# Altium schematic sheet 01_INTERFACE_CAN_0820.SchDoc
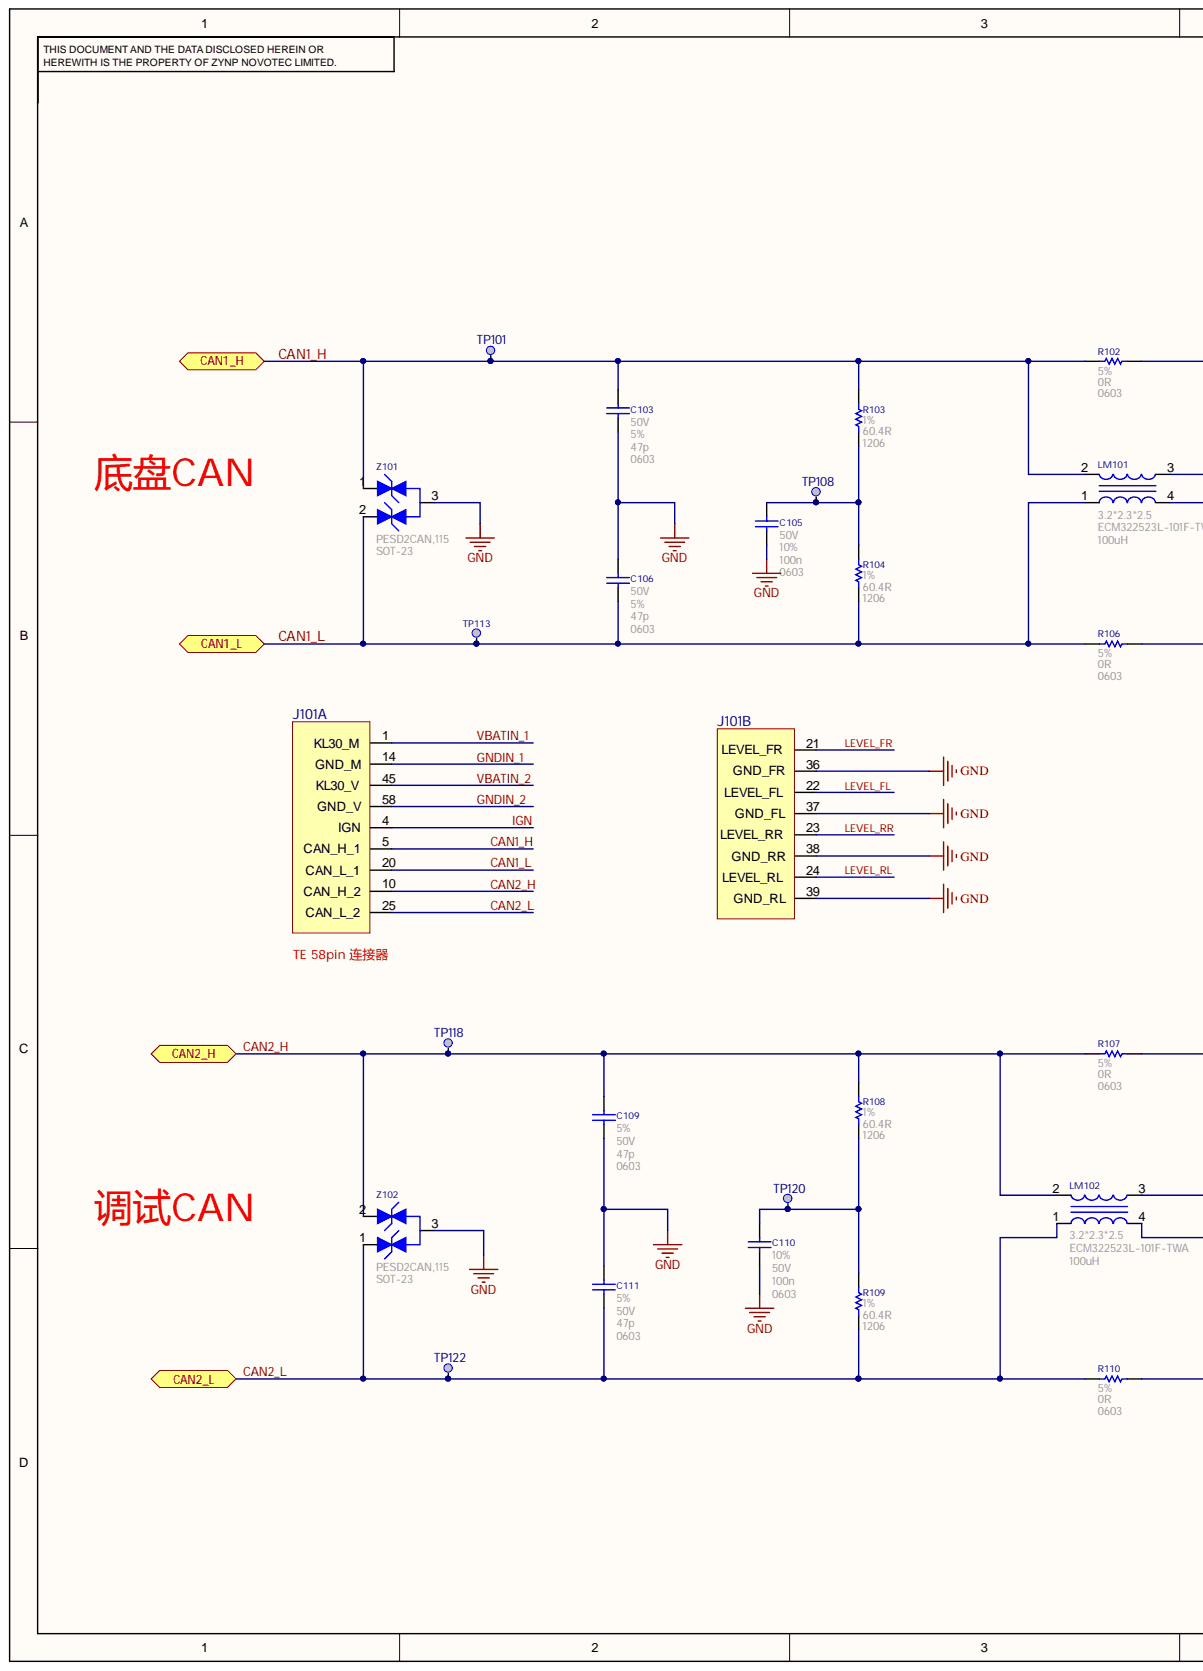
[diagram p0: 01_INTERFACE_CAN_0820.SchDoc, left]
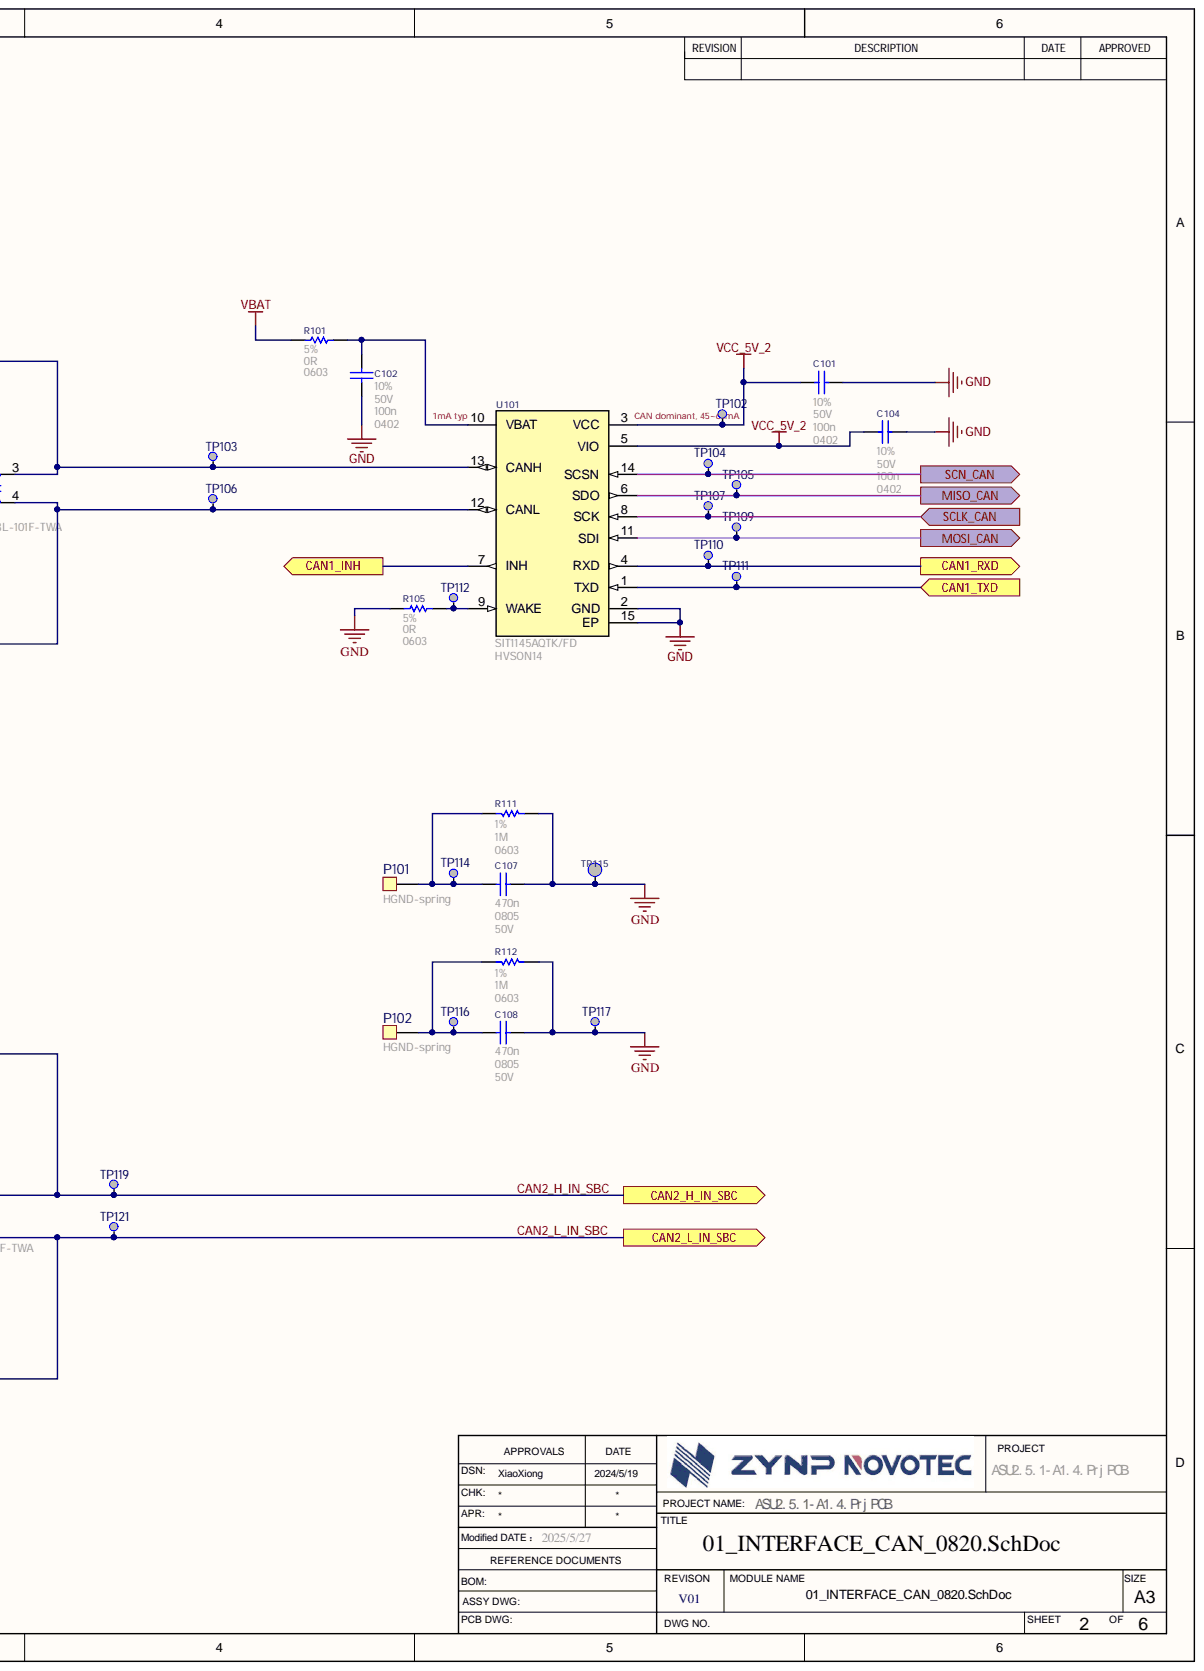
[diagram p1: 01_INTERFACE_CAN_0820.SchDoc, right]

## Parts
C101  value 100n  Tolerance: 10%  Voltage: 50V  [C0402]  (hidden comment: CAP)
C102  value 100n  Package: 0402  Tolerance: 10%  Voltage: 50V  [C0402]  (hidden comment: CAP)
C103  value 47p  Package: 0603  Tolerance: 5%  Voltage: 50V  [C0603]  (hidden comment: CAP)
C104  value 100n  Package: 0402  Tolerance: 10%  Voltage: 50V  [C0402]  (hidden comment: CAP)
C105  value 100n  Package: 0603  Tolerance: 10%  Voltage: 50V  [C0603]  (hidden comment: CAP)
C106  value 47p  Package: 0603  Tolerance: 5%  Voltage: 50V  [C0603]  (hidden comment: CAP)
C107  value 470n  Package: 0805  [C0805]  (hidden comment: CAP)
C108  value 470n  Package: 0805  Voltage: 50V  [C0805]  (hidden comment: CAP)
C109  value 47p  Package: 0603  Tolerance: 5%  Voltage: 50V  [C0603]  (hidden comment: CAP)
C110  value 100n  Package: 0603  Tolerance: 10%  Voltage: 50V  [C0603]  (hidden comment: CAP)
C111  value 47p  Package: 0603  Tolerance: 5%  Voltage: 50V  [C0603]  (hidden comment: CAP)
J101  (no value)  [CON_46 - 17PIN]  (parts A,B)
LM101  value 100uH  Package: 3.2*2.3*2.5  Part_number: ECM322523L-101F-TWA  [L-3.2*2.5]  (hidden comment: CAN Choke)
LM102  value 100uH  Package: 3.2*2.3*2.5  Part_number: ECM322523L-101F-TWA  [L-3.2*2.5]  (hidden comment: CAN Choke)
P101  HGND-spring  [PAD-HGND-SPRING]
P102  HGND-spring  [PAD-HGND-SPRING]
R101  value 0R  Package: 0603  Tolerance: 5%  [R0603]  (hidden comment: Res)
R102  value 0R  Package: 0603  Tolerance: 5%  [R0603]  (hidden comment: Res)
R103  value 60.4R  Package: 1206  Tolerance: 1%  [R1206]  (hidden comment: Res)
R104  value 60.4R  Package: 1206  Tolerance: 1%  [R1206]  (hidden comment: Res)
R105  value 0R  Package: 0603  Tolerance: 5%  [R0603]  (hidden comment: Res)
R106  value 0R  Package: 0603  Tolerance: 5%  [R0603]  (hidden comment: Res)
R107  value 0R  Package: 0603  Tolerance: 5%  [R0603]  (hidden comment: Res)
R108  value 60.4R  Package: 1206  Tolerance: 1%  [R1206]  (hidden comment: Res)
R109  value 60.4R  Package: 1206  Tolerance: 1%  [R1206]  (hidden comment: Res)
R110  value 0R  Package: 0603  Tolerance: 5%  [R0603]  (hidden comment: Res)
R111  value 1M  Tolerance: 1%  [R0603]  (hidden comment: Res)
R112  value 1M  Tolerance: 1%  [R0603]  (hidden comment: Res)
TP101  TEST Point  [TP-0.9]  (value not drawn)
TP102  TEST Point  [TP-0.9]  (value not drawn)
TP103  TEST Point  [TP-0.9]  (value not drawn)
TP104  TEST Point  [TP-0.9]  (value not drawn)
TP105  TEST Point  [TP-0.9]  (value not drawn)
TP106  TEST Point  [TP-0.9]  (value not drawn)
TP107  TEST Point  [TP-0.9]  (value not drawn)
TP108  TEST Point  [TP-0.9]  (value not drawn)
TP109  TEST Point  [TP-0.9]  (value not drawn)
TP110  TEST Point  [TP-0.9]  (value not drawn)
TP111  TEST Point  [TP-0.9]  (value not drawn)
TP112  TEST Point  [TP-0.9]  (value not drawn)
TP113  TEST Point  [TP-0.9]  (value not drawn)
TP114  TEST Point  [TP-0.9]  (value not drawn)
TP115  TEST Point  [PAD_1.5]  (value not drawn)
TP116  TEST Point  [TP-0.9]  (value not drawn)
TP117  TEST Point  [TP-0.9]  (value not drawn)
TP118  TEST Point  [TP-0.9]  (value not drawn)
TP119  TEST Point  [TP-0.9]  (value not drawn)
TP120  TEST Point  [TP-0.9]  (value not drawn)
TP121  TEST Point  [TP-0.9]  (value not drawn)
TP122  TEST Point  [TP-0.9]  (value not drawn)
U101  value SIT1145AQTK/FD  Part_number: SIT1145AQTK/FD  [HVSON14]  (hidden comment: CAN Transceiver)
Z101  Part_number: PESD2CAN,115  [SOT-23]  (hidden comment: Diode)
Z102  Part_number: PESD2CAN,115  [SOT-23]  (hidden comment: Diode)

## Ports
CAN1_H, CAN1_INH, CAN1_L, CAN1_RXD, CAN1_TXD, CAN2_H, CAN2_H_IN_SBC, CAN2_L, CAN2_L_IN_SBC, MISO_CAN, MOSI_CAN, SCLK_CAN, SCN_CAN

## Nets
GND: C101.1 C102.1 C103.1 C104.1 C105.1 C106.2 C107.2 C108.2 C109.1 C110.1 C111.2 J101.36(GND_FR) J101.37(GND_FL) J101.38(GND_RR) J101.39(GND_RL) R105.1 R111.2 R112.2 TP115.1 TP117.1 U101.2(GND) U101.15(EP) Z101.3 Z102.3
VBAT: R101.1
VCC_5V_2: C101.2 C104.2 TP102.1 U101.3(VCC) U101.5(VIO)
CAN1_H: C103.2 J101.5(CAN_H_1) LM101.2 R102.1 R103.2 TP101.1 Z101.1 ; port CAN1_H
CAN1_INH: U101.7(INH) ; port CAN1_INH
CAN1_L: C106.1 J101.20(CAN_L_1) LM101.1 R104.1 R106.1 TP113.1 Z101.2 ; port CAN1_L
CAN1_RXD: TP110.1 U101.4(RXD) ; port CAN1_RXD
CAN1_TXD: TP111.1 U101.1(TXD) ; port CAN1_TXD
CAN2_H: C109.2 J101.10(CAN_H_2) LM102.2 R107.1 R108.2 TP118.1 Z102.2 ; port CAN2_H
CAN2_H_IN_SBC: LM102.3 R107.2 TP119.1 ; port CAN2_H_IN_SBC
CAN2_L: C111.1 J101.25(CAN_L_2) LM102.1 R109.1 R110.1 TP122.1 Z102.1 ; port CAN2_L
CAN2_L_IN_SBC: LM102.4 R110.2 TP121.1 ; port CAN2_L_IN_SBC
GNDIN_1: J101.14(GND_M)
GNDIN_2: J101.58(GND_V)
IGN: J101.4(IGN)
LEVEL_FL: J101.22(LEVEL_FL)
LEVEL_FR: J101.21(LEVEL_FR)
LEVEL_RL: J101.24(LEVEL_RL)
LEVEL_RR: J101.23(LEVEL_RR)
MISO_CAN: TP105.1 U101.6(SDO) ; port MISO_CAN
MOSI_CAN: TP109.1 U101.11(SDI) ; port MOSI_CAN
SCLK_CAN: TP107.1 U101.8(SCK) ; port SCLK_CAN
SCN_CAN: TP104.1 U101.14(SCSN) ; port SCN_CAN
VBATIN_1: J101.1(KL30_M)
VBATIN_2: J101.45(KL30_V)
NetC102_2: C102.2 R101.2 U101.10(VBAT)
NetC105_2: C105.2 R103.1 R104.2 TP108.1
NetC107_1: C107.1 P101.1 R111.1 TP114.1
NetC108_1: C108.1 P102.1 R112.1 TP116.1
NetC110_2: C110.2 R108.1 R109.2 TP120.1
NetLM101_3: LM101.3 R102.2 TP103.1 U101.13(CANH)
NetLM101_4: LM101.4 R106.2 TP106.1 U101.12(CANL)
NetR105_2: R105.2 TP112.1 U101.9(WAKE)

## Library data of the parts
C101 C102 C104 = C_100n_50V_10%_GCM155R71H104KE02D: 112000115; Manufacturer: Murata; Location: Japan; Regulation: GCM155R71H104KE02D、Murata、100n、10%、50V、X7R、-55℃~125℃、0402; SAP_number: 10052237; Specification: RoHS AEC-Q200; Temperature_char: X7R; Type: 陶瓷电容; Component Kind: Standard; Library Name: RLC.SchLib; Part_number: GCM155R71H104KE02D; TC_number: 112000115; Temperature: -55℃~125℃
  C101: Package: 0402
C103 C106 C109 C111 = C_47p_50V_5%_GCM1885C1H470JA16D: GCM1885C1H470JA16D、Murata、47p、5%、50V、C0G、-55℃~125℃、0603; Manufacturer: Murata; a: 112000282; Location: Japan; SAP_number: 10052239; Specification: RoHS AEC-Q200; TC_number: 112000100; Temperature_char: C0G; Type: 陶瓷电容; Component Kind: Standard; Library Name: RLC.SchLib; Part_number: GCM1885C1H470JA16D; Regulation: GCM1885C1H470JA16D、Murata、47p、5%、50V、C0G、-55℃~125℃、0603; Temperature: -55℃~125℃
C105 C110 = C_100n_50V_10%_GCM188R71H104KA57D: 112000101; Manufacturer: Murata; Location: Japan; Regulation: GCM188R71H104KA57D、Murata、100n、10%、50V、X7R、-55℃~125℃、0603; SAP_number: 10055330; Specification: RoHS AEC-Q200; Temperature_char: X7R; Type: 陶瓷电容; Component Kind: Standard; Library Name: RLC.SchLib; Part_number: GCM188R71H104KA57D; TC_number: 112000101; Temperature: -55℃~125℃
C107 = C_470n_50V_10%_GCM21BR71H474KA55L: 112000116; Manufacturer: Murata; Location: Japan; Regulation: GCM21BR71H474KA55L、Murata、470n、10%、50V、X7R、-55℃~125℃ 、0805; SAP_number: 10056390; Specification: RoHS AEC-Q200; Temperature_char: X7R; Tolerance: 10%; Type: 陶瓷电容; Voltage: 50V; Component Kind: Standard; Library Name: RLC.SchLib; Part_number: GCM21BR71H474KA55L; TC_number: 112000116; Temperature: -55℃~125℃
C108 = C_470n_50V_10%_GCM21BR71H474KA55L: 112000116; Manufacturer: Murata; Location: Japan; Regulation: GCM21BR71H474KA55L、Murata、470n、10%、50V、X7R、-55℃~125℃ 、0805; SAP_number: 10056390; Specification: RoHS AEC-Q200; Temperature_char: X7R; Tolerance: 10%; Type: 陶瓷电容; Component Kind: Standard; Library Name: RLC.SchLib; Part_number: GCM21BR71H474KA55L; TC_number: 112000116; Temperature: -55℃~125℃
J101 = CON-58-17PIN: Component Kind: Standard; Library Name: Header.SchLib
LM101 LM102 = L_100uH_0.15A_-30%/+50%_ECM322523L-101F-TWA: ECM322523L-101F-TWA、HORUS、共模电感、100uH、-30%/+50%、0.15A、-40℃~150℃、3.2*2.5*2.3; Manufacturer: HORUS; Current: 0.15A; Location: China; Specification: RoHS AEC-Q200; Component Kind: Standard; Library Name: RLC.SchLib; Regulation: ECM322523L-101F-TWA、HORUS、共模电感、100uH、-30%/+50%、0.15A、-40℃~150℃、3.2*2.5*2.3; Temperature: -40℃~150℃; Tolerance: -30%/+50%; Type: 共模电感
P101 P102 = HGND-spring: B5G-20X30X031;CuBe;Au 1u" Over Ni50~120u"; Manufacturer: LAIRD; Component Kind: Standard; Library Name: Header.SchLib; Part_number: B5G-20X30X031; Regulation: B5G-20X30X031;CuBe;Au 1u" Over Ni50~120u"; Type: 接地弹片
R101 R102 R105-R107 R110 = R_0R_1/10W_5%_AC0603JR-070RL: Manufacturer: YAGEO; Location: taiwan; Power: 1/10W; Regulation: AC0603JR-070RL、YAGEO、0R、5%、0603; Specification: RoHS AEC-Q200; Component Kind: Standard; Library Name: RLC.SchLib; Part_number: AC0603JR-070RL; Temperature: -55℃～155℃; Type: 厚膜电阻
R103 R104 R108 R109 = R_60.4R_1/4W_1%_AC1206FR-0760R4L: AC1206FR-0760R4L、YAGEO、60.4R、1%、1206; Manufacturer: YAGEO; Location: taiwan; Power: 1/4W; Specification: RoHS AEC-Q200; Component Kind: Standard; Library Name: RLC.SchLib; Part_number: AC1206FR-0760R4L; Regulation: AC1206FR-0760R4L、YAGEO、60.4R、1%、1206; Temperature: -55℃～155℃; Type: 厚膜电阻
R111 R112 = R_1M_1/10W_1%_AC0603FR-071ML: Manufacturer: YAGEO; Location: taiwan; Power: 1/10W; Regulation: AC0603FR-071ML、YAGEO、1M、1%、0603; Specification: RoHS AEC-Q200; Component Kind: Standard; Library Name: RLC.SchLib; Package: 0603; Part_number: AC0603FR-071ML; Temperature: -55℃～155℃; Type: 厚膜电阻
TP101-TP114 TP116-TP122 = TEST_PIN_0.9: TP; Component Kind: Standard; Library Name: Header.SchLib; Package: TP-0.9; Type: test pin; Value: TEST
TP115 = TEST_PIN_1.5: TP; Component Kind: Standard; Library Name: Header.SchLib; Package: TP-1.5; Value: TEST
U101 = U_SIT1145AQTK/FD(HVSON14): SIT1145AQTK/FD、芯力特、CAN收发器、-40℃~150℃、HVSON14; Manufacturer: 芯力特; Pin counter: 14; Specification: RoHS AEC-Q100; Component Kind: Standard; Library Name: IC.SchLib; Package: HVSON14; Regulation: SIT1145AQTK/FD、芯力特、CAN收发器、-40℃~150℃、HVSON14; Temperature: -40℃~150℃; Type: CAN收发器
Z101 Z102 = D_24V_PESD2CAN,115: Manufacturer: Nexperia; Breakdown Current(Vbr): 24V; Power: 230W; Regulation: PESD2CAN,115、Nexperia、5A、24V、230W、-55℃~150℃、SOT-23; Specification: RoHS; Component Kind: Standard; Library Name: Diode.SchLib; Package: SOT-23; Reverse Voltage(Vr): 24V; Temperature: -55℃-150℃; type: 保护(抑制)二极管
